# Altium schematic sheet harmonicAnalyzer.SchDoc(harmonicAnalyzer)
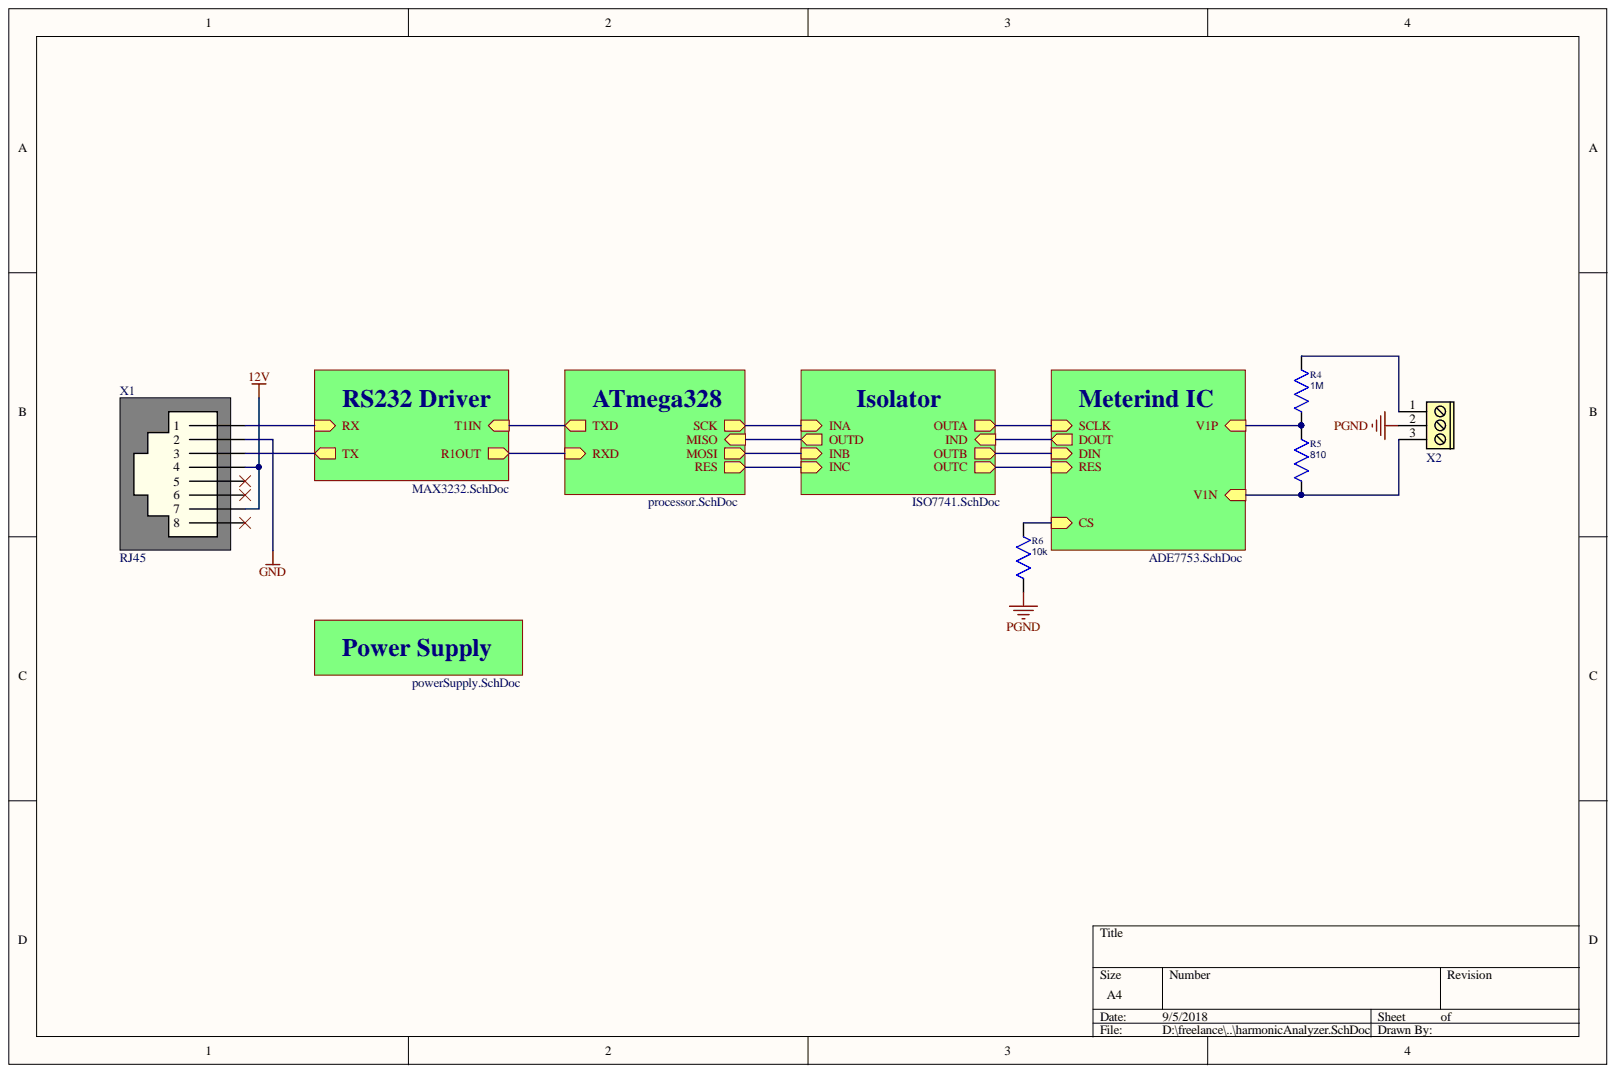
[diagram p0: harmonicAnalyzer.SchDoc]

## Parts
R4  1M  [R_0805]
R5  810  [R_0805]
R6  10k  [R_0603]
X1  RJ45  [RJ45]
X2  DG-300-3  [DG-300-5MM-3]  (value not drawn)

## Nets
12V: X1.4 X1.7
GND: X1.2
PGND: R6.2 X2.2
NetR4_1: R4.1 X2.1
NetR4_2: R4.2 R5.1
NetR5_2: R5.2 X2.3
NetR6_1: R6.1
NetX1_1: X1.1
NetX1_3: X1.3
NetX1_5: X1.5
NetX1_6: X1.6
NetX1_8: X1.8
IND:
MISO:
MOSI:
OUTA:
OUTB:
OUTC:
RES:
RXD:
SCK:
TXD:

## Library data of the parts
R4 R5 = R0805: Resistor; Library Name: AX_Altium_v2.3.IntLib; Component Kind: Standard
  R4: Value: 1M
  R5: Value: 810
R6 = R0603: Resistor; Value: 10k; Library Name: AX_Altium_v2.3.IntLib; Component Kind: Standard
X1 = RJ45: Outlet YH-55-05 8P8C 5521; Link: https://www.rcscomponents.kiev.ua/product/rozetka-yh-55-05-8p8c-5521-l-kls12-133-8p8c-w-o-2-01_8324.html; Library Name: SmartOutletIntegratedLibrary.IntLib; Component Kind: Standard
X2 = DG-300-3: Description: DG-300-3; Component Kind: Standard; Library Name: Light_Music_Integrated_Library.IntLib
